# Altium schematic sheet RFRadio.SchDoc(RFRadio)
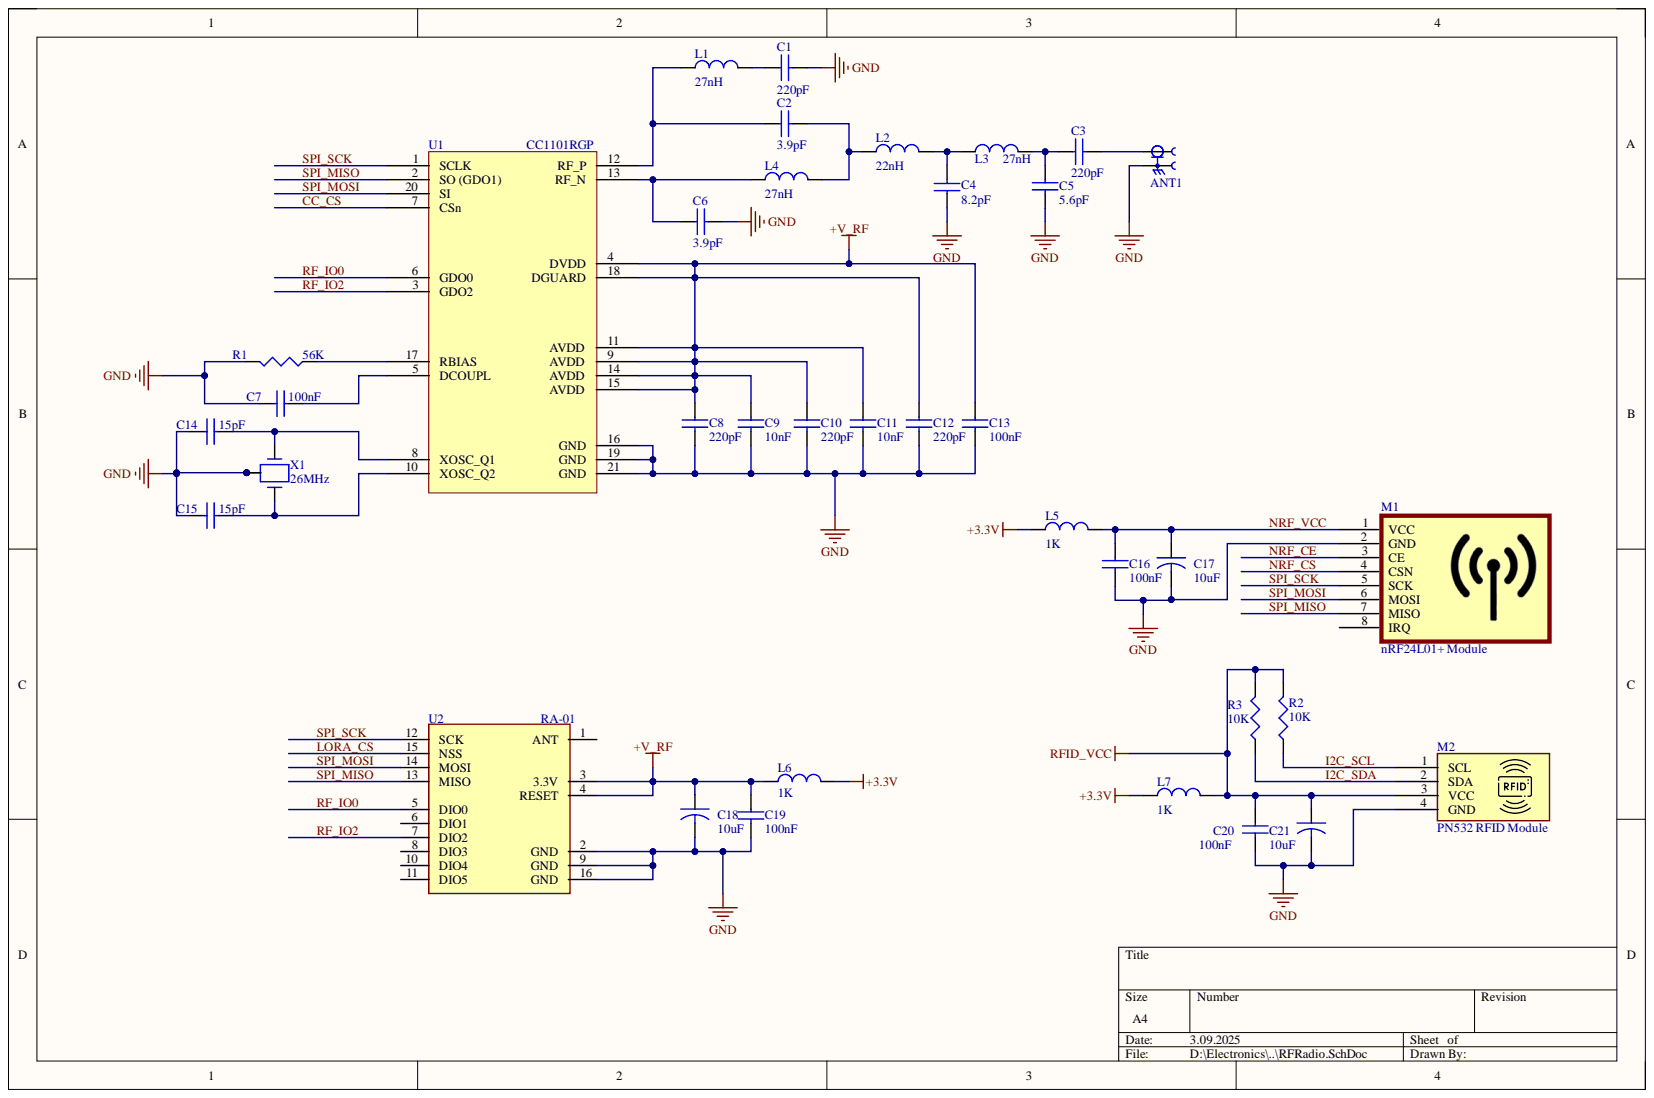
[diagram p0: RFRadio.SchDoc]

## Parts
ANT1  UFL Antenna  [UFL CONN]  (value not drawn)
C1  value 220pF  [0603 SMD Ceramic Capacitor]  (hidden comment: 0603_220pF)
C2  value 3.9pF  [0603 SMD Ceramic Capacitor]  (hidden comment: 0603_3.9pF)
C3  value 220pF  [0603 SMD Ceramic Capacitor]  (hidden comment: 0603_220pF)
C4  value 8.2pF  [0603 SMD Ceramic Capacitor]  (hidden comment: 0603_8.2pF)
C5  value 5.6pF  [0603 SMD Ceramic Capacitor]  (hidden comment: 0603_5.6pF)
C6  value 3.9pF  [0603 SMD Ceramic Capacitor]  (hidden comment: 0603_3.9pF)
C7  value 100nF  [0603 SMD Ceramic Capacitor]  (hidden comment: 0603_100nF)
C8  value 220pF  [0603 SMD Ceramic Capacitor]  (hidden comment: 0603_220pF)
C9  value 10nF  [0603 SMD Ceramic Capacitor]  (hidden comment: 0603_10nF)
C10  value 220pF  [0603 SMD Ceramic Capacitor]  (hidden comment: 0603_220pF)
C11  value 10nF  [0603 SMD Ceramic Capacitor]  (hidden comment: 0603_10nF)
C12  value 220pF  [0603 SMD Ceramic Capacitor]  (hidden comment: 0603_220pF)
C13  value 100nF  [0603 SMD Ceramic Capacitor]  (hidden comment: 0603_100nF)
C14  value 15pF  [0603 SMD Ceramic Capacitor]  (hidden comment: 0603_15pF)
C15  value 15pF  [0603 SMD Ceramic Capacitor]  (hidden comment: 0603_15pF)
C16  value 100nF  [0805 SMD Ceramic Capacitor]  (hidden comment: C0805C104)
C17  value 10uF  [A Case]  (hidden comment: T491A106)
C18  value 10uF  [A Case]  (hidden comment: T491A106)
C19  value 100nF  [0805 SMD Ceramic Capacitor]  (hidden comment: C0805C104)
C20  value 100nF  [0805 SMD Ceramic Capacitor]  (hidden comment: C0805C104)
C21  value 10uF  [A Case]  (hidden comment: T491A106)
L1  value 27nH  [0603 Inductor]  (hidden comment: 0603_27nH)
L2  value 22nH  [0603 Inductor]  (hidden comment: 0603_22nH)
L3  value 27nH  [0603 Inductor]  (hidden comment: 0603_27nH)
L4  value 27nH  [0603 Inductor]  (hidden comment: 0603_27nH)
L5  value 1K  [0805 Inductor]  (hidden comment: 0805Ferrite)
L6  value 1K  [0805 Inductor]  (hidden comment: 0805Ferrite)
L7  value 1K  [0805 Inductor]  (hidden comment: 0805Ferrite)
M1  value nRF24L01+ Module  [NRF24L01_Module]  (hidden comment: NRF24L01_SMD)
M2  value PN532 RFID Module  [PN532 RFID Module]  (hidden comment: RC522 RFID Module)
R1  value 56K  [0603 Resistor]  (hidden comment: 0603_56K%5)
R2  value 10K  [0805 Resistor]  (hidden comment: 0805_10K)
R3  value 10K  [0805 Resistor]  (hidden comment: 0805_10K)
U1  CC1101  value CC1101RGP  Manufacturer Code: CC1101RGP  [QFN-20]
U2  RA-01  [XCVR_RA-01H]
X1  value 26MHz  [TSX-3225]  (hidden comment: SMD XTAL 26MHz)

## Nets
+3.3V: L5.1 L6.1 L7.1
+V_RF: C8.1 C9.1 C10.1 C11.1 C12.1 C13.1 C18.1 C19.1 L6.2 U1.4(DVDD) U1.9(AVDD) U1.11(AVDD) U1.14(AVDD) U1.15(AVDD) U1.18(DGUARD) U2.3(3.3V) U2.4(RESET)
GND: ANT1.2 C1.2 C4.1 C5.2 C6.2 C7.1 C8.2 C9.2 C10.2 C11.2 C12.2 C13.2 C14.1 C15.1 C16.2 C17.2 C18.2 C19.2 C20.2 C21.2 M1.2(GND) M2.4(GND) R1.2 U1.16(GND) U1.19(GND) U1.21(GND) U2.2(GND) U2.9(GND) U2.16(GND) X1.2 X1.4
RFID_VCC: C20.1 C21.1 L7.2 M2.3(VCC) R2.2 R3.2
CC_CS: U1.7(CSn)
I2C_SCL: M2.1(SCL) R2.1
I2C_SDA: M2.2(SDA) R3.1
LORA_CS: U2.15(NSS)
NRF_CE: M1.3(CE)
NRF_CS: M1.4(CSN)
NRF_VCC: C16.1 C17.1 L5.2 M1.1(VCC)
RF_IO0: U1.6(GDO0) U2.5(DIO0)
RF_IO2: U1.3(GDO2) U2.7(DIO2)
SPI_MISO: M1.7(MISO) U1.2(SO_(GDO1)) U2.13(MISO)
SPI_MOSI: M1.6(MOSI) U1.20(SI) U2.14(MOSI)
SPI_SCK: M1.5(SCK) U1.1(SCLK) U2.12(SCK)
NetANT1_1: ANT1.1 C3.2
NetC1_1: C1.1 L1.2
NetC2_1: C2.1 L1.1 U1.12(RF_P)
NetC2_2: C2.2 L2.1 L4.2
NetC3_1: C3.1 C5.1 L3.2
NetC4_2: C4.2 L2.2 L3.1
NetC6_1: C6.1 L4.1 U1.13(RF_N)
NetC7_2: C7.2 U1.5(DCOUPL)
NetC14_2: C14.2 U1.8(XOSC_Q1) X1.1
NetC15_2: C15.2 U1.10(XOSC_Q2) X1.3
NetR1_1: R1.1 U1.17(RBIAS)

Not connected: M1 8(IRQ); U2 1(ANT) 6(DIO1) 8(DIO3) 10(DIO4) 11(DIO5)

## Library data of the parts
ANT1 = UFL Antenna: Manufacturer: HIROSE; Manufacturer Code: U.FL-R-SMT-1(10); Component Kind: Standard; Farnell Code: 1688077; Library Name: UFL_CONN.SCHLIB; MOQ: 1; Unit Price: 0,578 €
C1 C3 C8 C10 C12 = 0603_220pF: MLCC (1608) 0603 220pF 50VDC ±5% X7R; Manufacturer: SAMSUNG; Manufacturer Code: CL10B221JB8NNNC; Voltage: 50V; Component Kind: Standard; Dielectric Characteristic: X7R; Library Name: Capacitors.SchLib; MOQ: 50; Package: 0603; Price Unit: $; Tolerance: ±5%; Unit Price: 0.00767
  C1: Value: 220pF
C2 C6 = 0603_3.9pF: Manufacturer: SAMSUNG; Manufacturer Code: CL03C3R9BA3GNNC; Dielectric Characteristic: C0G/NP0; Tolerance: ±0.5%; Voltage: 25V; Component Kind: Standard; Library Name: Capacitors.SchLib; MOQ: 254; Package: 0603; Price Unit: $; Unit Price: 0,01170
C4 = 0603_8.2pF: MLCC (0603) 0201 3.9pF 25VDC ±0.1pF C0G (NP0); Manufacturer: YAGEO; Manufacturer Code: CC0201DPNPO9BN8R2; Dielectric Characteristic: C0G/NP0; Tolerance: ±0.5%; Voltage: 50V; Component Kind: Standard; Library Name: Capacitors.SchLib; MOQ: 100; Package: 0603; Price Unit: $; Unit Price: 0.00299
C5 = 0603_5.6pF: MLCC (1608) 0603 5.6pF 50VDC ±0.25pF C0G (NP0); Manufacturer: YAGEO; Manufacturer Code: CC0603CRNPO9BN5R6; Dielectric Characteristic: C0G/NP0; Tolerance: ±0.5%; Voltage: 50V; Component Kind: Standard; Library Name: Capacitors.SchLib; MOQ: 25; Package: 0603; Price Unit: $; Unit Price: 0.00975
C7 C13 = 0603_100nF: Manufacturer: MULTICOMP; Manufacturer Code: MC0603F104Z500CT; Dielectric Characteristic: Y5V; Voltage: 50V; Component Kind: Standard; Farnell Code: 1759123; Library Name: Capacitors.SchLib; MOQ: 10; Package: 0603; Unit Price: 0,0207 €
C9 C11 = 0603_10nF: Manufacturer: MULTICOMP; Manufacturer Code: MC0603F103Z500CT; Dielectric Characteristic: Y5V; Voltage: 50V; Component Kind: Standard; Farnell Code: 1759104; Library Name: Capacitors.SchLib; MOQ: 10; Package: 0603; Unit Price: 0,0174 €
C14 C15 = 0603_15pF: MLCC (0603) 0201 15pF 50V 5% NP0; Manufacturer: MURATA; Manufacturer Code: GRT0335C1H150JA02D; Dielectric Characteristic: C0G/NP0; Component Kind: Standard; Library Name: Capacitors.SchLib; MOQ: 50; Package: 0603; Price Unit: $; Tolerance: ±5%; Unit Price: 0.00317; Voltage: 50V
C16 C19 C20 = C0805C104: Manufacturer: KEMET; Manufacturer Code: C0805C104J5RACTU; Voltage: 50V; Component Kind: Standard; Farnell Code: 1414663; Library Name: Capacitors.SchLib; Package: 0805; Unit Price: 0,06 €
C17 C18 C21 = T491A106: Manufacturer: KEMET; Manufacturer Code: T491A106K006AT; Voltage: 6V3; Component Kind: Standard; Farnell Code: 1457412; Library Name: Capacitors.SchLib; Unit Price: 0,143 €
L1 L3 L4 = 0603_27nH: INDUCTOR FIXED 0603 27nH 500mA SMD; Manufacturer: CHILISIN; Manufacturer Code: CLH1608T-27NJ-S; Tolerance: ±5%; Component Kind: Standard; DC Current Rating: 500mA; Library Name: Inductors.SchLib; Price Unit: $; Unit Price: 0.03233
L2 = 0603_22nH: INDUCTOR FIXED 0603 22nH 500mA SMD; Manufacturer: CORE MASTER; Manufacturer Code: HCL1608-22NJ-N; Tolerance: ±5%; Component Kind: Standard; DC Current Rating: 500mA; Library Name: Inductors.SchLib; Price Unit: $; Unit Price: 0.02611
L5-L7 = 0805Ferrite: Manufacturer: TDK; Manufacturer Code: MMZ2012R102A; Current: 500mA; Component Kind: Standard; Farnell Code: 1669719; Library Name: FerriteBead.SchLib; Unit Price: 0,059 €
M1 = NRF24L01_SMD: Manufacturer: Nordic Semiconductor; Manufacturer Code: NRF24L01+SMD; Component Kind: Standard; Library Name: NRF24L01_Module.SchLib; Unit Price: 3$
M2 = RC522 RFID Module: PN532 RFID Module; Component Kind: Standard; Library Name: PN532_RFID_Module.IntLib; Unit Price: 2.00 $
R1 = 0603_56K%5: Manufacturer: ROYALOHM; Manufacturer Code: 0603SAJ0563T5E; Power Rating: 100mW; Temperature Coefficient: ±100ppm/°C; Tolerance: ±5%; Component Kind: Standard; Library Name: Resistors.SchLib; MOQ: 100; Package: 0603; Price Unit: $; Unit Price: 0.00095
R2 R3 = 0805_10K: Manufacturer: MULTICOMP; Manufacturer Code: MC 0.1W 0805 1% 10K; Tolerance: ±1%; Component Kind: Standard; Farnell Code: 9332391; Library Name: Resistors.SchLib; Unit Price: 0,03 €
U1 = CC1101: 500kbps ISM SPI QFN-20-EP(4x4) RF Transceiver ICs ROHS; Manufacturer: Texas Instruments; Component Kind: Standard; Library Name: CC11xx.SchLib; Package: QFN-20; Price Unit: $; Unit Price: 1.51508
U2 = RA-01: General ISM < 1GHz LoRa™ Transceiver Module 410MHz ~ 525MHz Antenna Not Included Surface Mount; Manufacturer: AI-Thinker; Component Kind: Standard; Digikey Code: 4570-RA-01TR-ND; Library Name: RA-01.IntLib; Manufacturer Code: RA-01; Unit Price: 5.3155 $; Value: RA-01
X1 = SMD XTAL 26MHz: Manufacturer: EPSON; Manufacturer Code: TSX-3225 26.0000MF09Z-AC0; Load Capacitance: 9pF; Tolerance: ± 10ppm; Component Kind: Standard; Library Name: Crystal.SchLib; Price Unit: $; Unit Price: 0.39
